# Altium schematic sheet Power.SchDoc
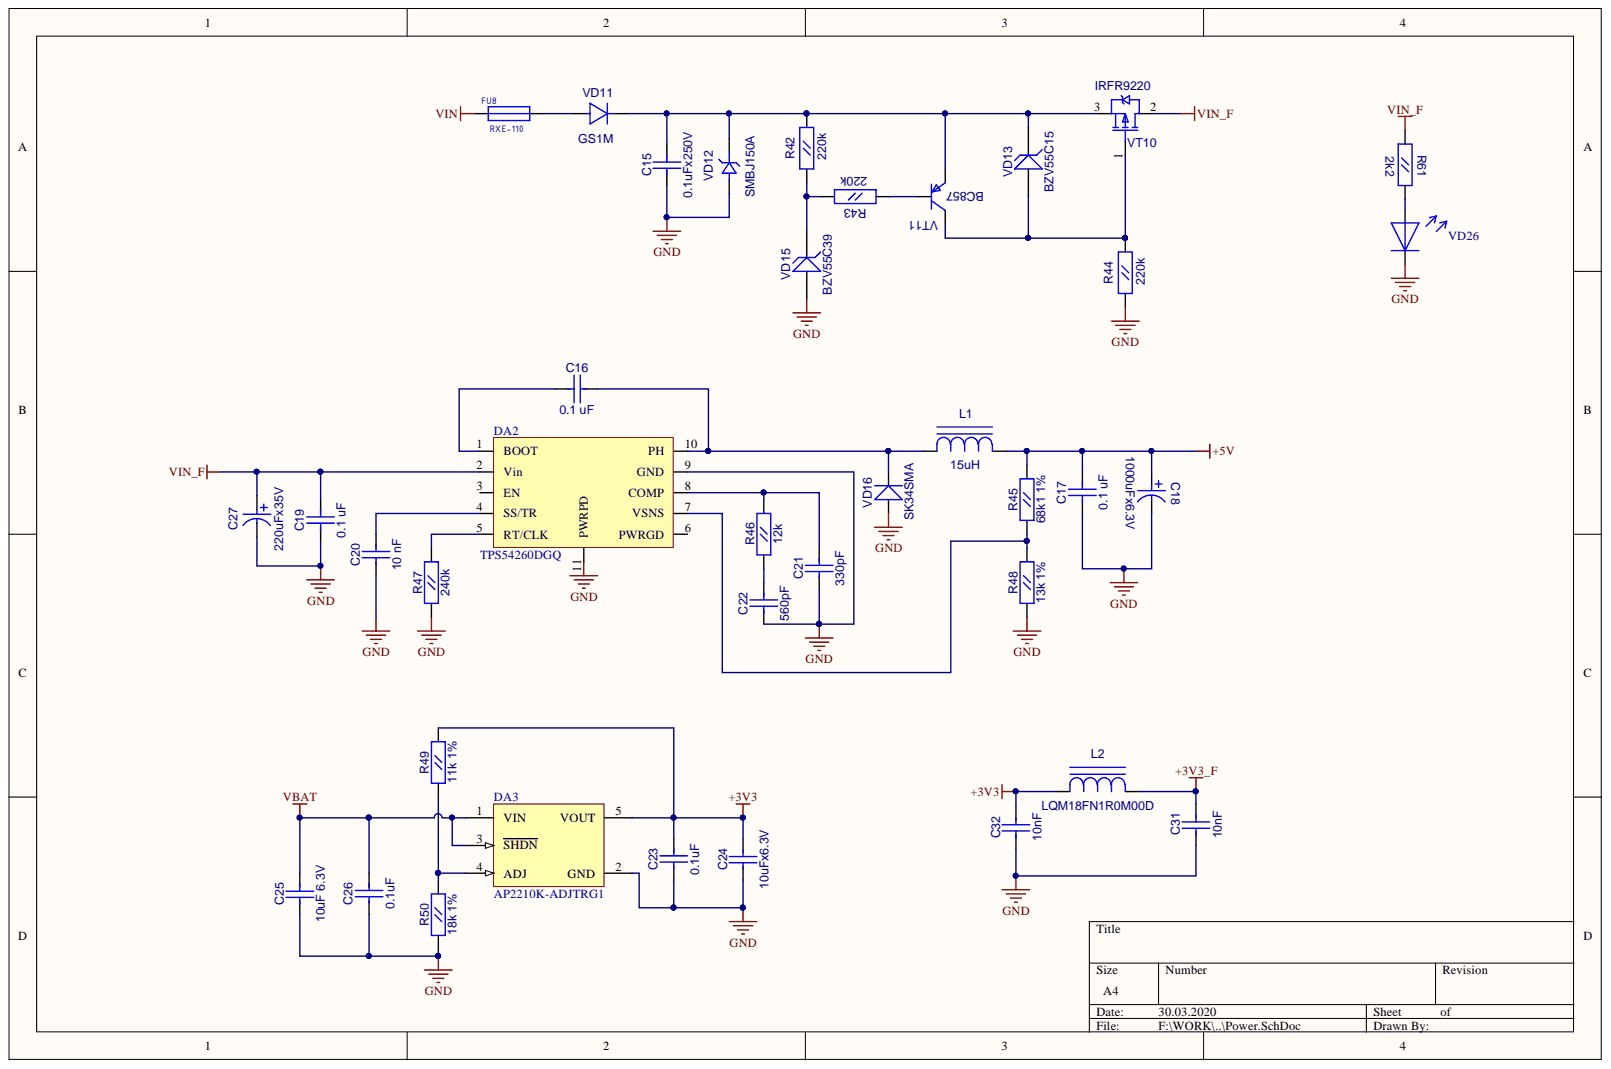
[diagram p0: Power.SchDoc]

## Parts
C15  0.1uFx250V  [CC1206]
C16  0.1 uF  [CC0603]
C17  0.1 uF  [CC0603]
C18  1000uFx6.3V  [CC8X3.5]
C19  0.1 uF  [CC0603]
C20  10 nF  [CC0603]
C21  330pF  [CC0603]
C22  560pF  [CC0603]
C23  0.1uF  [CC0603]
C24  10uFx6.3V  [CC0805]
C25  10uF 6.3V  [CC0805]
C26  0.1uF  [CC0603]
C27  220uFx35V  [CC16X10_CAP0.5(1)(Primary)]
C31  10nF  [CC0603]
C32  10nF  [CC0603]
DA2  TPS54260DGQ  [S-PDSO-G10]
DA3  AP2210K-ADJTRG1  [SOT23-5]
FU8  RXE-110  [RXE-110]
L1  15uH  [SDR0805]
L2  value LQM18FN1R0M00D  [L0603]  (hidden comment: L0603)
R42  220k  [RC0603]
R43  220k  [RC0603]
R44  220k  [RC0603]
R45  68k1 1%  [RC0603]
R46  12k  [RC0603]
R47  240k  [RC0603]
R48  13k 1%  [RC0603]
R49  11k 1%  [RC0603]
R50  18k 1%  [RC0603]
R61  2k2  [RC0603]
VD11  GS1M  [SMA]
VD12  SMBJ150A  [SMB]
VD13  BZV55C15  [SOD-80]
VD15  BZV55C39  [SOD-80]
VD16  SK34SMA  [SMA]
VD26  LED_0805  [LED0805]  (value not drawn)
VT10  IRFR9220  [D-PAK(TO-252AA)]
VT11  BC857  [SOT-23(123)]

## Nets
+3V3: C23.1 C24.2 C32.2 DA3.5(VOUT) L2.1 R49.2
+3V3_F: C31.2 L2.2
+5V: C17.1 C18.1 L1.2 R45.2
GND: C15.1 C17.2 C18.2 C19.2 C20.2 C21.2 C22.2 C23.2 C24.1 C25.1 C26.2 C27.1 C31.1 C32.1 DA2.9(GND) DA2.11(PWRPD) DA3.2(GND) R44.1 R47.1 R48.1 R50.1 VD12.1 VD15.1 VD16.1 VD26.2
VBAT: C25.2 C26.1 DA3.1(VIN) DA3.3(SHDN)
VIN: FU8.1
VIN_F: C19.1 C27.2 DA2.2(Vin) R61.1 VT10.2
NetC15_2: C15.2 R42.2 VD11.2 VD12.2 VD13.2 VT10.3 VT11.2
NetC16_1: C16.1 DA2.10(PH) L1.1 VD16.2
NetC16_2: C16.2 DA2.1(BOOT)
NetC20_1: C20.1 DA2.4(SS/TR)
NetC21_1: C21.1 DA2.8(COMP) R46.2
NetC22_1: C22.1 R46.1
NetDA2_5: DA2.5(RT/CLK) R47.2
NetDA2_7: DA2.7(VSNS) R45.1 R48.2
NetDA3_4: DA3.4(ADJ) R49.1 R50.2
NetFU8_2: FU8.2 VD11.1
NetR42_1: R42.1 R43.2 VD15.2
NetR43_1: R43.1 VT11.1
NetR44_2: R44.2 VD13.1 VT10.1 VT11.3
NetR61_2: R61.2 VD26.1

Not connected: DA2 3(EN) 6(PWRGD)

## Library data of the parts
C15 = CC1206: Component Kind: Standard; Description: CC1206; Value: 0.1uFx250V; Library Name: gprs_modem_2.SchLib
C16 C17 C19-C23 C26 C31 C32 = CC0603: Component Kind: Standard; Description: CC0603; Library Name: gprs_modem_2.SchLib
  C16 C17 C19: Value: 0.1 uF
  C20: Value: 10 nF
  C21: Value: 330pF
  C22: Value: 560pF
  C23 C26: Value: 0.1uF
  C31 C32: Value: 10nF
C18 = CAP0.35: Component Kind: Standard; Description: CAP0.35; Value: 1000uFx6.3V; Library Name: gprs_modem_2.SchLib
C24 C25 = CC0805: Component Kind: Standard; Description: CC0805; Library Name: gprs_modem_2.SchLib
  C24: Value: 10uFx6.3V
  C25: Value: 10uF 6.3V
C27 = CAP0.5(1): Component Kind: Standard; Description: CAP0.5(1); Value: 220uFx35V; Library Name: gprs_modem_2.SchLib
DA3 = MCP1824T-ADJE/OT: 300 mA, Low Voltage, Low Quiescent Current LDO Regulator, 5-Pin SOT-223, Extended Temperature, Tape and Reel; Component Kind: Standard; ComponentLink1Description: Manufacturer Link; ComponentLink1URL: http://www.microchip.com; ComponentLink2Description: Datasheet; ComponentLink2URL: http://ww1.microchip.com/downloads/en/DeviceDoc/22070a.pdf; ComponentLink3Description: Packaging Specification; ComponentLink3URL: http://ww1.microchip.com/downloads/en/PackagingSpec/00049AW.pdf; DatasheetVersion: revA, Aug-2007; PackageDescription: 5-Lead Plastic Small Outline Transistor (DC) [SOT-223]; PackageReference: SOT-223-DC5; PackageVersion: revAW, Oct-2008; Published: 29-Jan-2009; Library Name: gprs_modem_2.SchLib; Publisher: Altium Limited
L1 = SDR0805: Component Kind: Standard; Description: SDR0805; Value: 15uH; Library Name: gprs_modem_2.SchLib
R42-R50 R61 = RC0603: Component Kind: Standard; Description: RC0603; Library Name: gprs_modem_2.SchLib
  R42-R44: Value: 220k
  R45: Value: 68k1 1%
  R46: Value: 12k
  R47: Value: 240k
  R48: Value: 13k 1%
  R49: Value: 11k 1%
  R50: Value: 18k 1%
  R61: Value: 2k2
VD11 = GS1M: Value: {Value}; Component Kind: Standard; Description: GS1M; Library Name: gprs_modem_2.SchLib
VD12 = SMBJ: Component Kind: Standard; Description: SMBJ; Value: SMBJ150A; Library Name: gprs_modem_2.SchLib
VD13 = BZV55C12: Zener Voltage Regulator Diode; Component Kind: Standard; Simulation: BZX84C10LT1; Value: BZV55C15; Library Name: gprs_modem_2.SchLib
VD15 = BZV55C12: Zener Voltage Regulator Diode; ComponentField6: Pin 2 is not connected and not drawn.; ComponentField7: Published 29-Sep-2000; OriginalName: BXV55C39; Component Kind: Standard; Simulation: BZX84C10LT1; Value: BZV55C39; Library Name: gprs_modem_2.SchLib
VD16 = SK34SMA: Schottky Rectifier; Value: {Value}; Component Kind: Standard; Library Name: gprs_modem.SchLib
VT10 = IRFR9220: HEXFET Power MOSFET; ComponentField7: Published 8-Jun-2000; OriginalName: IRLML5103; Component Kind: Standard; Value: IRFR9220; Library Name: gprs_modem_2.SchLib
VT11 = BC857B: PNP 100ma transistor; ComponentField7: Published 29-Sep-2000; OriginalName: BC846BLT1; Component Kind: Standard; Simulation: BC846BLT1; Value: BC857; Library Name: gprs_modem_2.SchLib
DA2 = TPS54260DGQ: Component Kind: Standard; Library Name: gprs_modem_2.SchLib
FU8 = RXE-110: Component Kind: Standard; Description: RXE-110; Library Name: gprs_modem_2.SchLib
L2 = L0603: Component Kind: Standard; Description: L0603; Library Name: gprs_modem_2.SchLib
VD26 = LED_0805: Component Kind: Standard; Value: LED_0805; Library Name: gprs_modem_2.SchLib
